# Altium schematic sheet Sensors.SchDoc(Sensors)
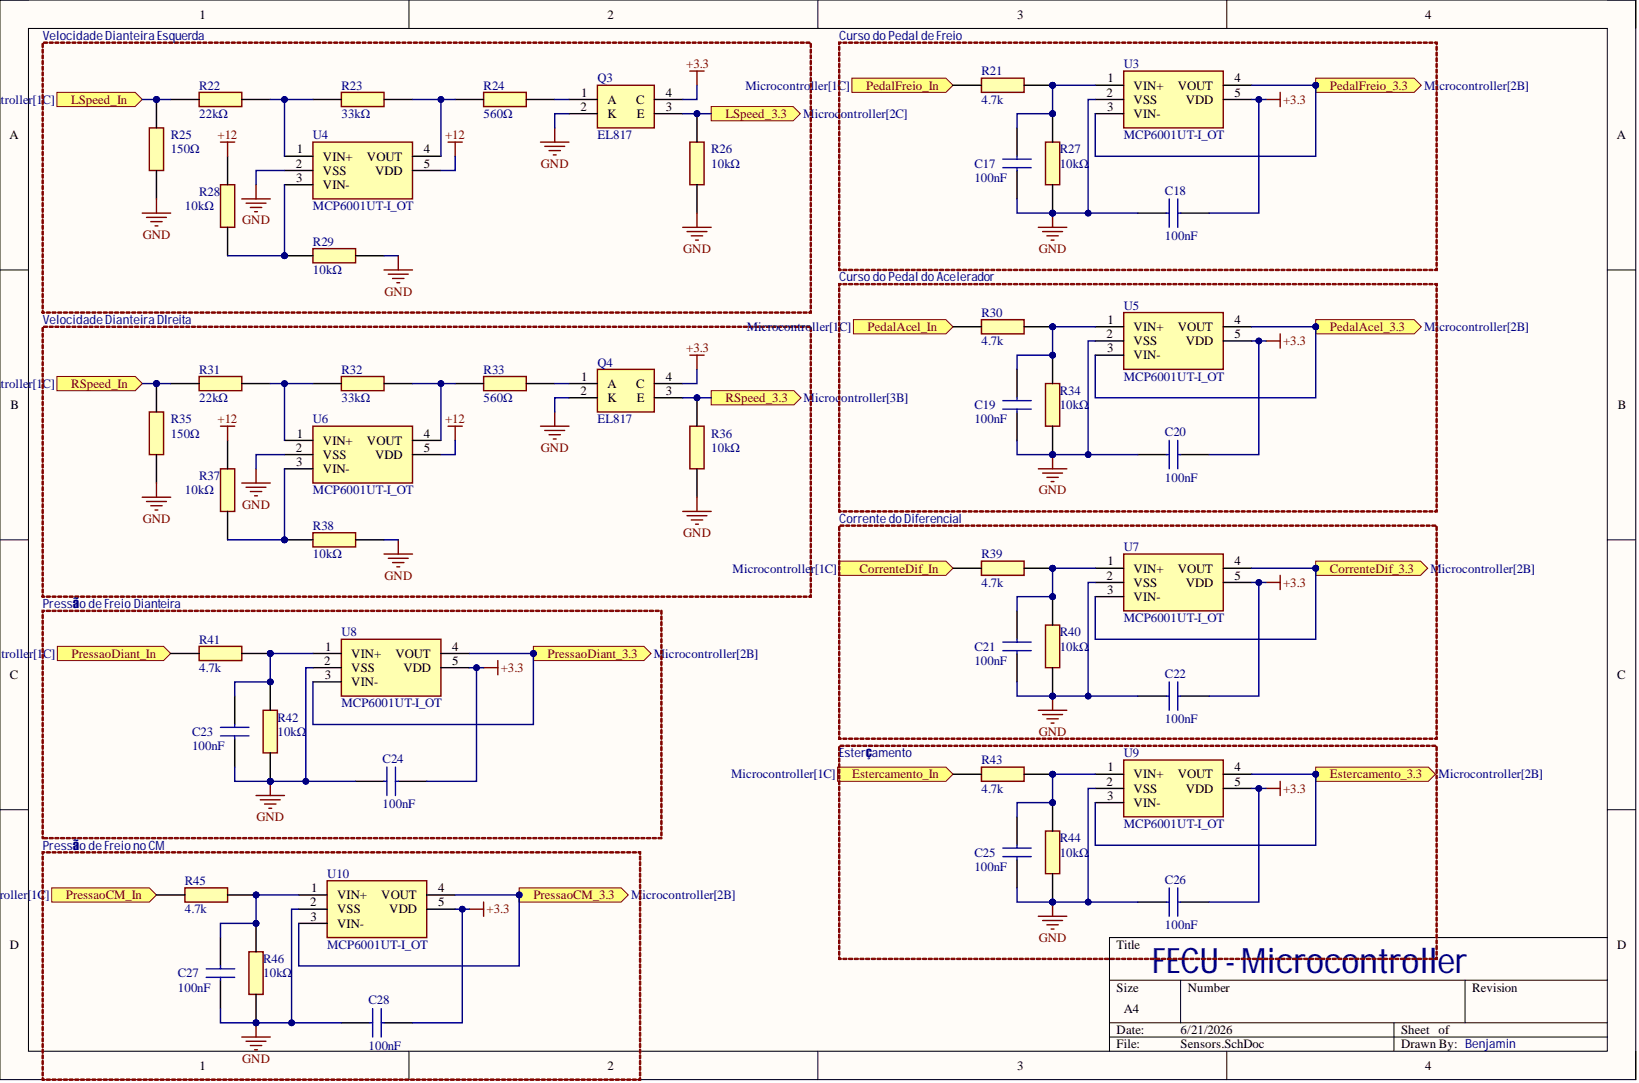
[diagram p0: Sensors.SchDoc]

## Parts
C17  100nF
C18  100nF
C19  100nF
C20  100nF
C21  100nF
C22  100nF
C23  100nF
C24  100nF
C25  100nF
C26  100nF
C27  100nF
C28  100nF
Q3  EL817
Q4  EL817
R21  4.7k
R22  22kΩ
R23  33kΩ
R24  560Ω
R25  150Ω
R26  10kΩ
R27  10kΩ
R28  10kΩ
R29  10kΩ
R30  4.7k
R31  22kΩ
R32  33kΩ
R33  560Ω
R34  10kΩ
R35  150Ω
R36  10kΩ
R37  10kΩ
R38  10kΩ
R39  4.7k
R40  10kΩ
R41  4.7k
R42  10kΩ
R43  4.7k
R44  10kΩ
R45  4.7k
R46  10kΩ
U3  MCP6001UT-I_OT
U4  MCP6001UT-I_OT
U5  MCP6001UT-I_OT
U6  MCP6001UT-I_OT
U7  MCP6001UT-I_OT
U8  MCP6001UT-I_OT
U9  MCP6001UT-I_OT
U10  MCP6001UT-I_OT

## Ports
CorrenteDif_3.3, CorrenteDif_In, Estercamento_3.3, Estercamento_In, LSpeed_3.3, LSpeed_In, PedalAcel_3.3, PedalAcel_In, PedalFreio_3.3, PedalFreio_In, PressaoCM_3.3, PressaoCM_In, PressaoDiant_3.3, PressaoDiant_In, RSpeed_3.3, RSpeed_In

## Nets
+3.3: C18.1 C20.1 C22.1 C24.1 C26.1 C28.1 Q3.4(C) Q4.4(C) U3.5(VDD) U5.5(VDD) U7.5(VDD) U8.5(VDD) U9.5(VDD) U10.5(VDD)
+12: R28.1 R37.1 U4.5(VDD) U6.5(VDD)
GND: C17.1 C18.2 C19.1 C20.2 C21.1 C22.2 C23.1 C24.2 C25.1 C26.2 C27.1 C28.2 Q3.2(K) Q4.2(K) R25.1 R26.2 R27.1 R29.2 R34.1 R35.1 R36.2 R38.2 R40.1 R42.1 R44.1 R46.1 U3.2(VSS) U4.2(VSS) U5.2(VSS) U6.2(VSS) U7.2(VSS) U8.2(VSS) U9.2(VSS) U10.2(VSS)
CorrenteDif_3.3: U7.3(VIN-) U7.4(VOUT) ; port CorrenteDif_3.3
CorrenteDif_In: R39.1 ; port CorrenteDif_In
Estercamento_3.3: U9.3(VIN-) U9.4(VOUT) ; port Estercamento_3.3
Estercamento_In: R43.1 ; port Estercamento_In
LSpeed_3.3: Q3.3(E) R26.1 ; port LSpeed_3.3
LSpeed_In: R22.1 R25.2 ; port LSpeed_In
PedalAcel_3.3: U5.3(VIN-) U5.4(VOUT) ; port PedalAcel_3.3
PedalAcel_In: R30.1 ; port PedalAcel_In
PedalFreio_3.3: U3.3(VIN-) U3.4(VOUT) ; port PedalFreio_3.3
PedalFreio_In: R21.1 ; port PedalFreio_In
PressaoCM_3.3: U10.3(VIN-) U10.4(VOUT) ; port PressaoCM_3.3
PressaoCM_In: R45.1 ; port PressaoCM_In
PressaoDiant_3.3: U8.3(VIN-) U8.4(VOUT) ; port PressaoDiant_3.3
PressaoDiant_In: R41.1 ; port PressaoDiant_In
RSpeed_3.3: Q4.3(E) R36.1 ; port RSpeed_3.3
RSpeed_In: R31.1 R35.2 ; port RSpeed_In
NetC17_2: C17.2 R21.2 R27.2 U3.1(VIN+)
NetC19_2: C19.2 R30.2 R34.2 U5.1(VIN+)
NetC21_2: C21.2 R39.2 R40.2 U7.1(VIN+)
NetC23_2: C23.2 R41.2 R42.2 U8.1(VIN+)
NetC25_2: C25.2 R43.2 R44.2 U9.1(VIN+)
NetC27_2: C27.2 R45.2 R46.2 U10.1(VIN+)
NetQ3_1: Q3.1(A) R24.2
NetQ4_1: Q4.1(A) R33.2
NetR22_2: R22.2 R23.1 U4.1(VIN+)
NetR23_2: R23.2 R24.1 U4.4(VOUT)
NetR28_2: R28.2 R29.1 U4.3(VIN-)
NetR31_2: R31.2 R32.1 U6.1(VIN+)
NetR32_2: R32.2 R33.1 U6.4(VOUT)
NetR37_2: R37.2 R38.1 U6.3(VIN-)

## Library data of the parts
C17-C28 = CL21B104KBCNNNC: Capacitor; Manufacturer_Name: SAMSUNG; Arrow Part Number: CL21B104KBCNNNC; Arrow Price/Stock: https://www.arrow.com/en/products/cl21b104kbcnnnc/samsung-electro-mechanics?region=europe; Component Kind: Standard; Datasheet Link: https://datasheet.datasheetarchive.com/originals/distributors/Datasheets_SAMA/86a3eaf0882763ef32124d5901f9b833.pdf; Height: 0.95mm; Manufacturer_Part_Number: CL21B104KBCNNNC; Mouser Part Number: 187-CL21B104KBCNNNC; Mouser Price/Stock: https://www.mouser.co.uk/ProductDetail/Samsung-Electro-Mechanics/CL21B104KBCNNNC?qs=349EhDEZ59pEfpnm5yBEmQ%3D%3D
Q3 Q4 = EL817_S1__C__TU_: Phototransistor; Manufacturer_Name: Everlight; Manufacturer_Part_Number: EL817(S1)(C)(TU); Arrow Part Number: EL817(S1)(C)(TU); Arrow Price/Stock: https://www.arrow.com/en/products/el817s1-c-tu/everlight-electronics?utm_currency=USD&region=europe; Component Kind: Standard; Datasheet Link: https://eu.mouser.com/datasheet/2/143/201407061833256242-365628.pdf; Height: 3.9mm; Mouser Part Number: 638-EL817S1CTU; Mouser Price/Stock: https://www.mouser.co.uk/ProductDetail/Everlight/EL817S1CTU?qs=8PzhAHr7IdNLRo4JydPHmQ%3D%3D
R21 R30 R39 R41 R43 R45 = CRT0805-BY-4701ELF: Resistor; Manufacturer_Name: Bourns; Component Kind: Standard; Datasheet Link: https://www.bourns.com/docs/Product-Datasheets/crt.pdf; Height: 0.65mm; Manufacturer_Part_Number: CRT0805-BY-4701ELF; Mouser Part Number: 652-CRT0805BY4701ELF; Mouser Price/Stock: https://www.mouser.co.uk/ProductDetail/Bourns/CRT0805-BY-4701ELF?qs=fgHA1UgJI8DqnIGe6nGx8w%3D%3D
R22 R31 = RC0805FR-0722KL: Resistor; Manufacturer_Name: KEMET; Arrow Part Number: RC0805FR-0722KL; Arrow Price/Stock: https://www.arrow.com/en/products/rc0805fr-0722kl/yageo; Component Kind: Standard; Datasheet Link: http://www.yageo.com/documents/recent/PYu-RC_Group_51_RoHS_L_10.pdf; Height: 0.6mm; Manufacturer_Part_Number: RC0805FR-0722KL; Mouser Part Number: 603-RC0805FR-0722KL; Mouser Price/Stock: https://www.mouser.co.uk/ProductDetail/YAGEO/RC0805FR-0722KL?qs=ngJqVwYVsdVbc7Rh0xIeHw%3D%3D
R23 R32 = 0805W8F3302T5E: Resistor; Manufacturer_Name: UNI-ROYAL(Uniroyal Elec); Component Kind: Standard; Datasheet Link: https://datasheet.lcsc.com/lcsc/2110251830_UNI-ROYAL-Uniroyal-Elec-0805W8F3302T5E_C17633.pdf; Height: 0.65mm; Manufacturer_Part_Number: 0805W8F3302T5E
R24 R33 = RC0805FR-07560RL: Resistor; Manufacturer_Name: KEMET; Arrow Part Number: RC0805FR-07560RL; Arrow Price/Stock: https://www.arrow.com/en/products/rc0805fr-07560rl/yageo?region=nac; Component Kind: Standard; Datasheet Link: http://www.yageo.com/documents/recent/PYu-RC_Group_51_RoHS_L_10.pdf; Height: 0.6mm; Manufacturer_Part_Number: RC0805FR-07560RL; Mouser Part Number: 603-RC0805FR-07560RL; Mouser Price/Stock: https://www.mouser.co.uk/ProductDetail/YAGEO/RC0805FR-07560RL?qs=g6xwsc4j%252B%2Foqaguphwrcog%3D%3D
R25 R35 = RC0805FR-07150RL: Resistor; Manufacturer_Name: KEMET; Arrow Part Number: RC0805FR-07150RL; Arrow Price/Stock: https://www.arrow.com/en/products/rc0805fr-07150rl/yageo; Component Kind: Standard; Datasheet Link: http://www.yageo.com/documents/recent/PYu-RC_Group_51_RoHS_L_10.pdf; Height: 0.6mm; Manufacturer_Part_Number: RC0805FR-07150RL; Mouser Part Number: 603-RC0805FR-07150RL; Mouser Price/Stock: https://www.mouser.co.uk/ProductDetail/Yageo/RC0805FR-07150RL?qs=8Y8p%252BasKcI6SRkoIp3y4Wg%3D%3D
R26-R29 R34 R36-R38 R40 R42 R44 R46 = RC0805FR-0710KL: Resistor; Manufacturer_Name: YAGEO; Arrow Part Number: RC0805FR-0710KL; Arrow Price/Stock: https://www.arrow.com/en/products/rc0805fr-0710kl/yageo?utm_currency=USD&region=europe; Component Kind: Standard; Datasheet Link: https://www.yageo.com/upload/media/product/productsearch/datasheet/rchip/PYu-RC_Group_51_RoHS_L_11.pdf; Height: 0.6mm; Manufacturer_Part_Number: RC0805FR-0710KL; Mouser Part Number: 603-RC0805FR-0710KL; Mouser Price/Stock: https://www.mouser.co.uk/ProductDetail/YAGEO/RC0805FR-0710KL?qs=7bY8808IzHq6vrU3BeiiYw%3D%3D
U3-U10 = MCP6001UT-I_OT: Integrated Circuit; Manufacturer_Name: Microchip; Manufacturer_Part_Number: MCP6001UT-I/OT; Arrow Part Number: MCP6001UT-I/OT; Arrow Price/Stock: https://www.arrow.com/en/products/mcp6001ut-iot/microchip-technology?utm_currency=USD&region=nac; Component Kind: Standard; Datasheet Link: http://componentsearchengine.com/Datasheets/3/MCP6001UT-I_OT.pdf; Height: 1.45mm; Mouser Part Number: 579-MCP6001UT-I/OT; Mouser Price/Stock: https://www.mouser.co.uk/ProductDetail/Microchip-Technology/MCP6001UT-I-OT?qs=Sez7gRs8XSU2iw24kVuIOw%3D%3D
